# Altium schematic sheet karadio.power.SchDoc
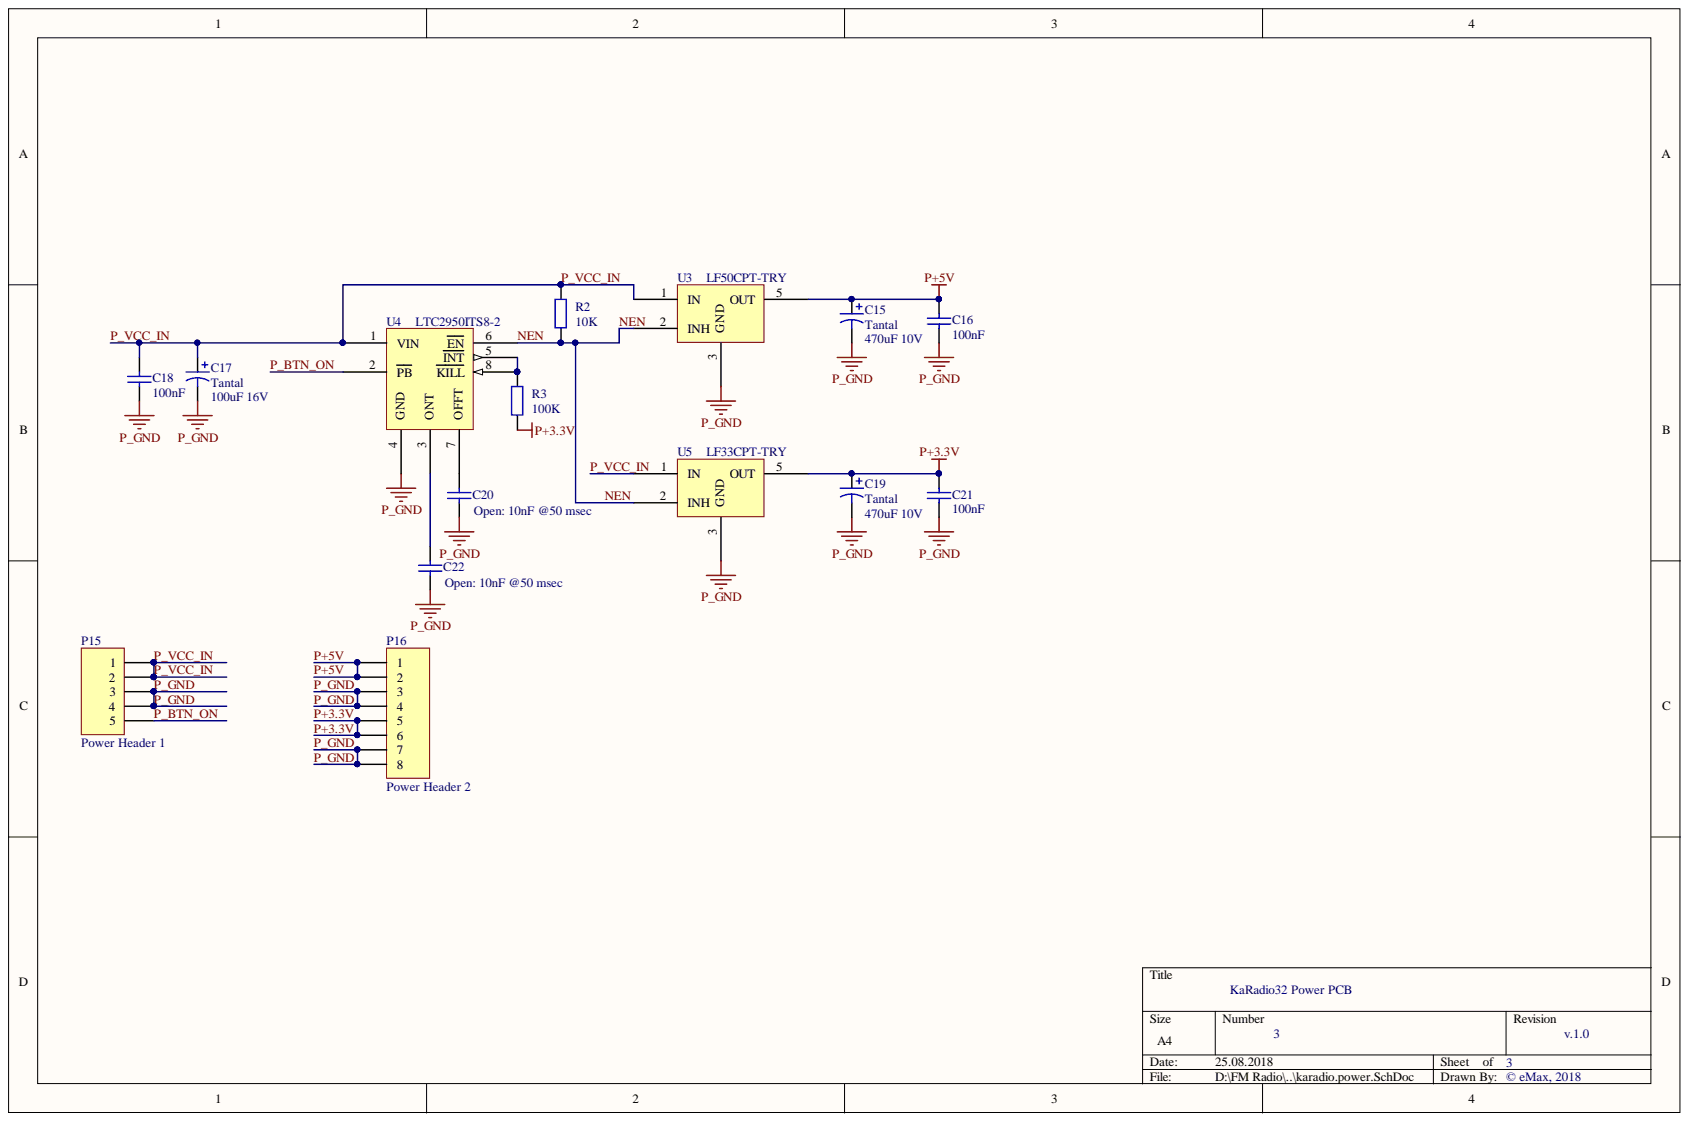
[diagram p0: karadio.power.SchDoc]

## Parts
C15  Tantal  value 470uF 10V  [TANTAL_E]
C16  (no value)  [0805]
C17  Tantal  value 100uF 16V  [TANTAL_C]
C18  (no value)  [0805]
C19  Tantal  value 470uF 10V  [TANTAL_E]
C20  (no value)  [0805]
C21  (no value)  [0805]
C22  (no value)  [0805]
P15  Power Header 1  [HDR1X5]
P16  Power Header 2  [HDR1X8]
R2  value 10K  [0805]  (hidden comment: Res2)
R3  value 100K  [0805]  (hidden comment: Res2)
U3  LF50CPT-TRY  [PPAK]
U4  LTC2950ITS8-2  [TSOT-23-8]
U5  LF33CPT-TRY  [PPAK]

## Nets
NEN: R2.1 U3.2(INH) U4.6(EN) U5.2(INH)
P+3.3V: C19.1 C21.1 P16.5 P16.6 R3.1 U5.5(OUT)
P+5V: C15.1 C16.1 P16.1 P16.2 U3.5(OUT)
P_BTN_ON: P15.5 U4.2(PB)
P_GND: C15.2 C16.2 C17.2 C18.2 C19.2 C20.1 C21.2 C22.1 P15.3 P15.4 P16.3 P16.4 P16.7 P16.8 U3.3(GND) U4.4(GND) U5.3(GND)
P_VCC_IN: C17.1 C18.1 P15.1 P15.2 R2.2 U3.1(IN) U4.1(VIN) U5.1(IN)
NetC20_2: C20.2 U4.7(OFFT)
NetC22_2: C22.2 U4.3(ONT)
NetR3_2: R3.2 U4.5(INT) U4.8(KILL)

## Library data of the parts
C15 C19 = Cap Pol1: Polarized Capacitor (Radial); Value: 470uF 10V; Component Kind: Standard; LatestRevisionDate: 17-Jul-2002; LatestRevisionNote: Re-released for DXP Platform.; Library Name: Miscellaneous Devices.IntLib; PackageDescription: Polarized Capacitor (Radial); 2 Leads; PackageReference: RB7.6-15; Published: 8-Jun-2000; Signal Integrity: Cap Pol; Simulation: CAP; Publisher: Altium Limited
C16 C18 C21 = Cap Semi: Capacitor (Semiconductor SIM Model); : 100nF; Component Kind: Standard; LatestRevisionDate: 17-Jul-2002; LatestRevisionNote: Re-released for DXP Platform.; Library Name: Miscellaneous Devices.IntLib; PackageReference: C1206; Published: 8-Jun-2000; Signal Integrity: Cap Semi; Simulation: CAP; Publisher: Altium Limited
C17 = Cap Pol1: Polarized Capacitor (Radial); Component Kind: Standard; LatestRevisionDate: 17-Jul-2002; LatestRevisionNote: Re-released for DXP Platform.; Library Name: Miscellaneous Devices.IntLib; PackageDescription: Polarized Capacitor (Radial); 2 Leads; PackageReference: RB7.6-15; Published: 8-Jun-2000; Signal Integrity: Cap Pol; Simulation: CAP; Publisher: Altium Limited
C20 C22 = Cap Semi: Capacitor (Semiconductor SIM Model); Open: 10nF @50 msec; Component Kind: Standard; LatestRevisionDate: 17-Jul-2002; LatestRevisionNote: Re-released for DXP Platform.; Library Name: Miscellaneous Devices.IntLib; PackageReference: C1206; Published: 8-Jun-2000; Signal Integrity: Cap Semi; Simulation: CAP; Publisher: Altium Limited
P15 = Header 5: Header, 5-Pin; Component Kind: Standard; LatestRevisionDate: 17-Jul-2002; LatestRevisionNote: Re-released for DXP Platform.; Library Name: Miscellaneous Connectors.IntLib; Signal Integrity: Connector; Publisher: Altium Limited
P16 = Header 8: Header, 8-Pin; Component Kind: Standard; LatestRevisionDate: 17-Jul-2002; LatestRevisionNote: Re-released for DXP Platform.; Library Name: Miscellaneous Connectors.IntLib; Signal Integrity: Connector; Publisher: Altium Limited
R2 R3 = Res2: Resistor; Component Kind: Standard; LatestRevisionDate: 17-Jul-2002; LatestRevisionNote: Re-released for DXP Platform.; PackageReference: AXIAL-0.4; Published: 8-Jun-2000; Signal Integrity: Res; Simulation: RESISTOR; Library Name: maxsat.SchLib; Publisher: Altium Limited
U3 = LF50CPT-TRY: +5V Very low drop voltage regulator with inhibit function; Component Kind: Standard; Library Name: karadio.SchLib
U4 = LTC2950-2: LTC2950ITS8-2 On/Off Controller; Component Kind: Standard; Library Name: karadio.SchLib
U5 = LF33CPT-TRY: +3.3V Very low drop voltage regulator with inhibit function; Component Kind: Standard; Library Name: karadio.SchLib
